# Altium schematic sheet EVB-NORA-B2_SCHEM_0001_Power.SchDoc(EVB-NORA-B2_SCHEM_0001_Power)
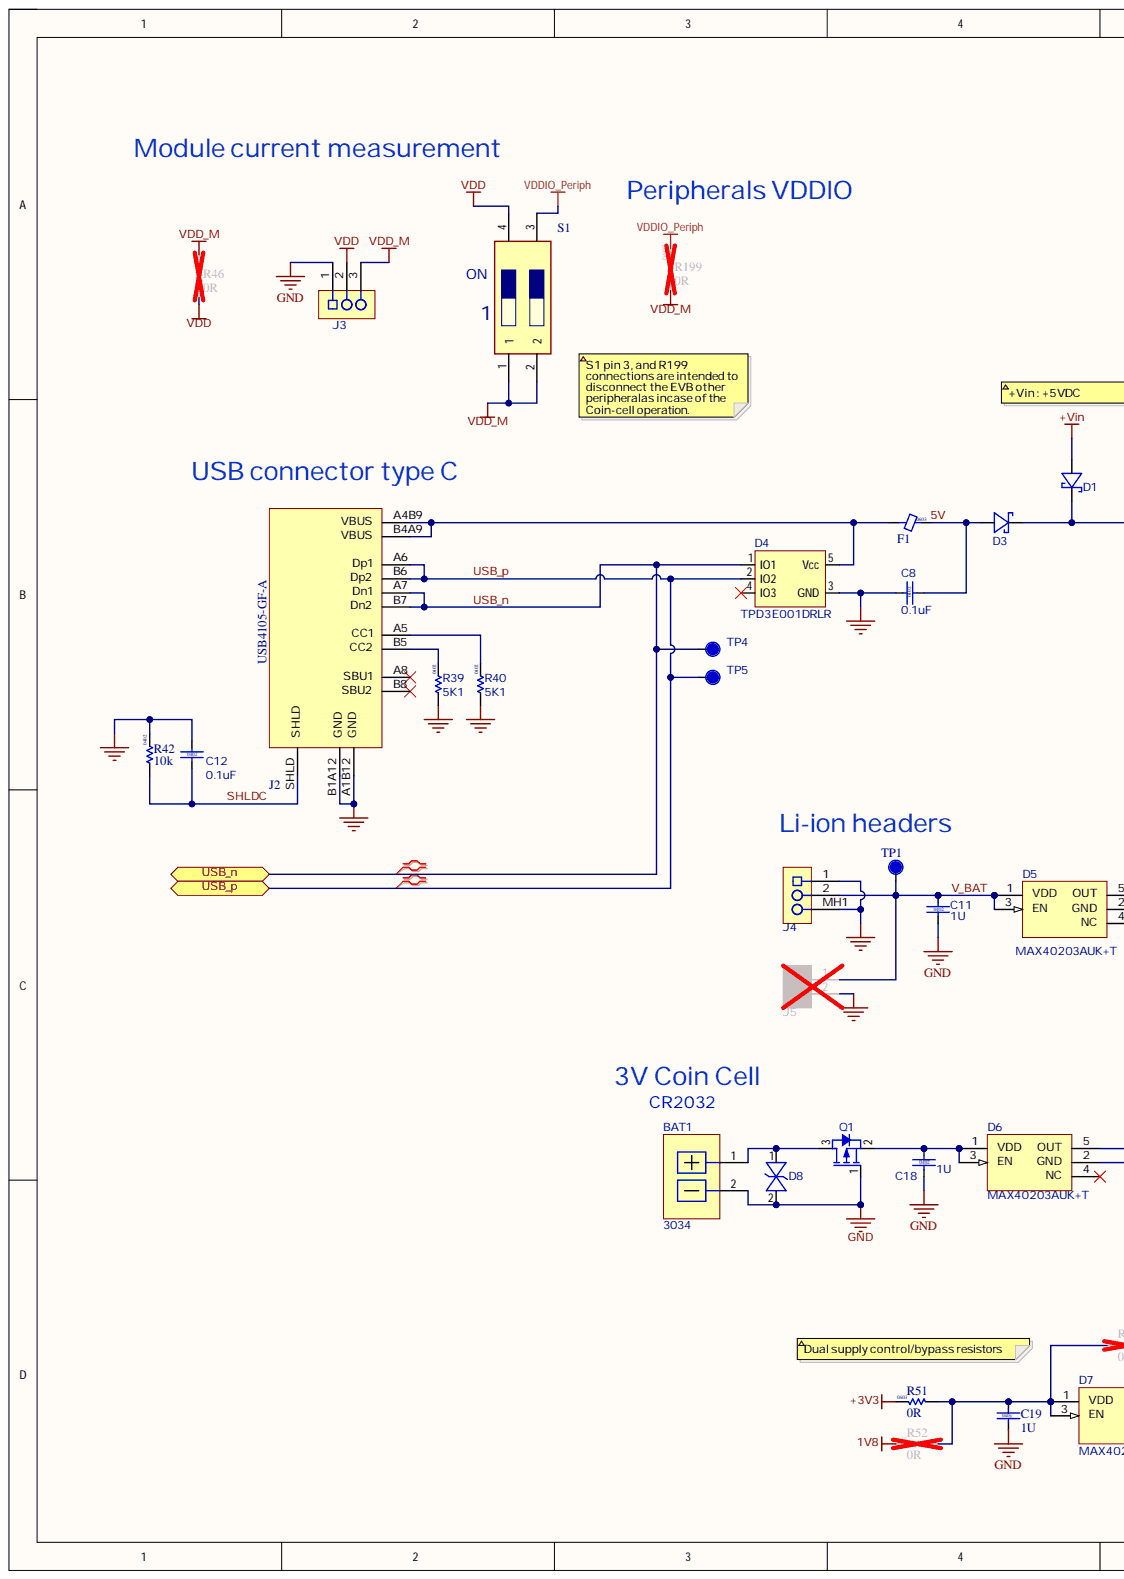
[diagram p0: EVB-NORA-B2_SCHEM_0001_Power.SchDoc, left]
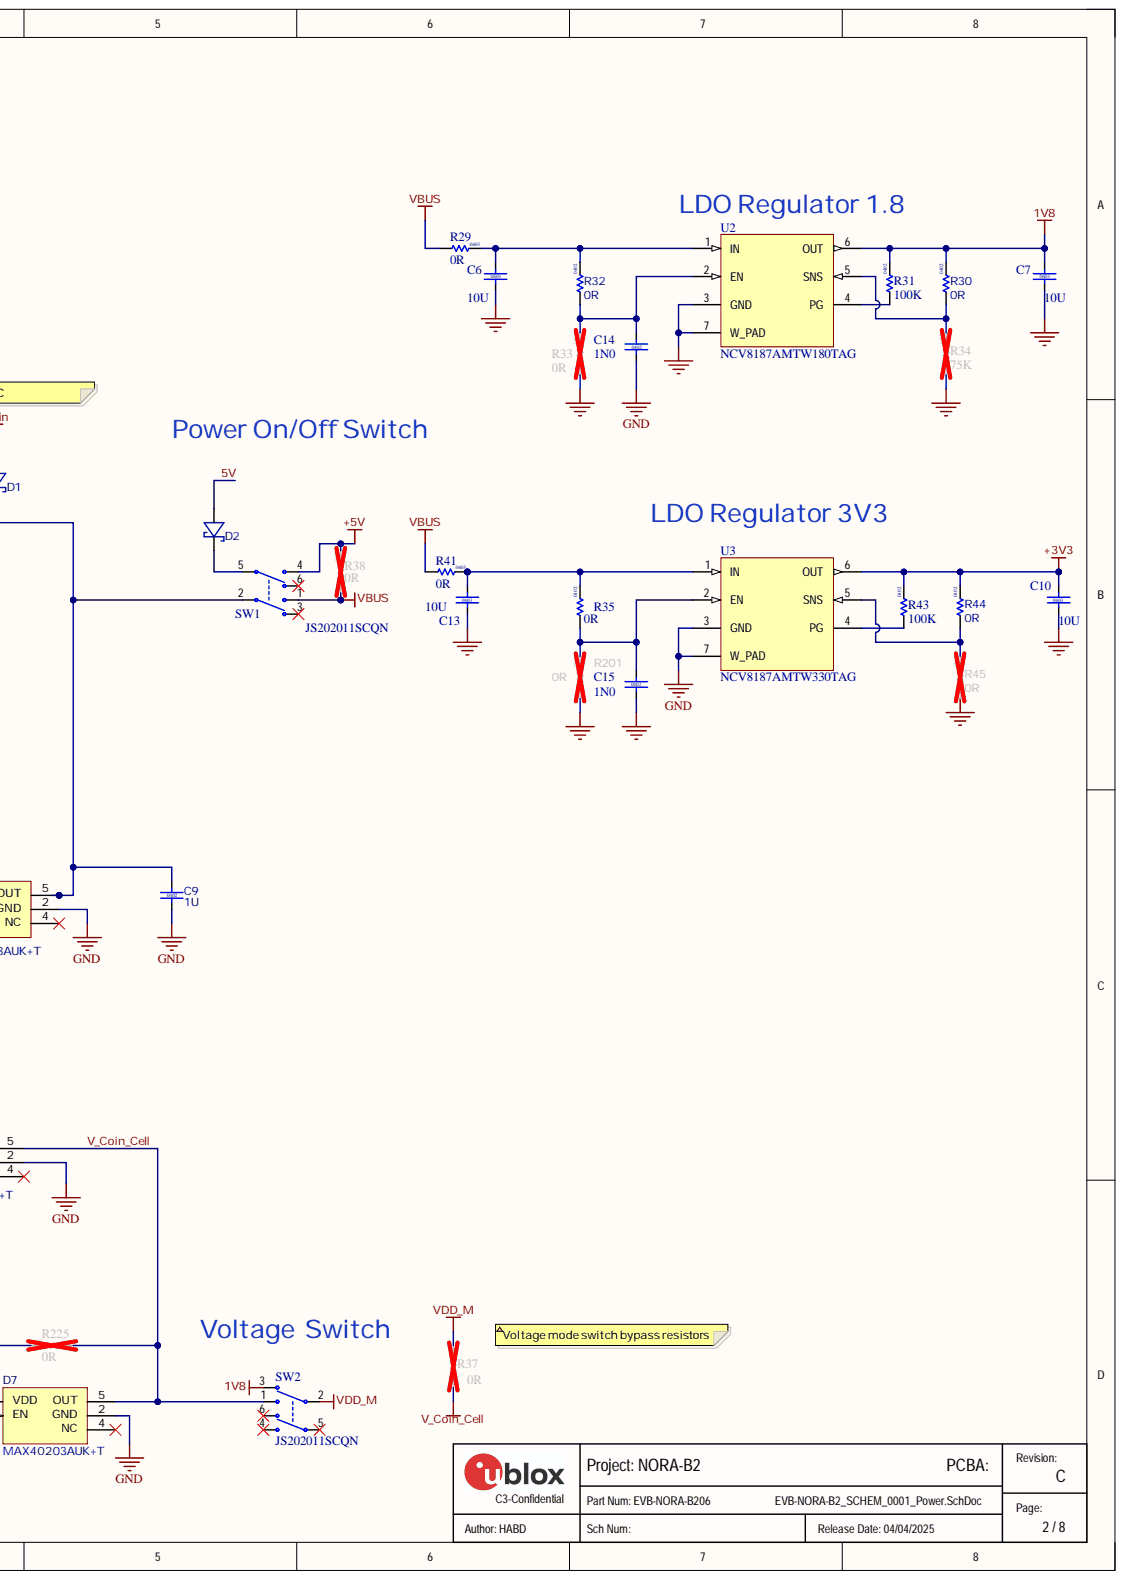
[diagram p1: EVB-NORA-B2_SCHEM_0001_Power.SchDoc, right]

## Parts
BAT1  value 3034  [3034]  (hidden comment: UBXH03-0000009)
C6  value 10U  Size: 0603  [CAP_0603_1750Lx950Wx850H_600x1000Pad_900G_FLX]  (hidden comment: UBXH01-0000310)
C7  value 10U  Size: 0603  [CAP_0603_1750Lx950Wx850H_600x1000Pad_900G_FLX]  (hidden comment: UBXH01-0000310)
C8  value 0.1uF  Size: 0402  [Cap 0402 HD]  (hidden comment: 120-00016)
C9  value 1U  Size: 0402  [Cap 0402 HD]  (hidden comment: UBXH01-0000248)
C10  value 10U  Size: 0603  [CAP_0603_1750Lx950Wx850H_600x1000Pad_900G_FLX]  (hidden comment: UBXH01-0000310)
C11  value 1U  Size: 0402  [Cap 0402 HD]  (hidden comment: UBXH01-0000248)
C12  value 0.1uF  Size: 0402  [Cap 0402 HD]  (hidden comment: 120-00016)
C13  value 10U  Size: 0603  [CAP_0603_1750Lx950Wx850H_600x1000Pad_900G_FLX]  (hidden comment: UBXH01-0000310)
C14  value 1N0  Size: 0402  [Cap 0402 HD]  (hidden comment: UBXH01-0000013)
C15  value 1N0  Size: 0402  [Cap 0402 HD]  (hidden comment: UBXH01-0000013)
C18  value 1U  Size: 0402  [Cap 0402 HD]  (hidden comment: UBXH01-0000248)
C19  value 1U  Case/Package: 0x0y  [Cap 0402 HD]
D1  110-00005  [SOD-123F_U]  (value not drawn)
D2  110-00005  [SOD-123F_U]  (value not drawn)
D3  110-00005  [SOD-123F_U]  (value not drawn)
D4  Manufacturer P/N: TPD3E001DRLR  [SOT-553]  (hidden comment: 110-00018)
D5  value MAX40203AUK+T  Manufacturer P/N: MAX40203AUK+T  [SOT-23-5_2]  (hidden comment: UBXH12-0000539)
D6  value MAX40203AUK+T  Manufacturer P/N: MAX40203AUK+T  [SOT-23-5_2]  (hidden comment: UBXH12-0000539)
D7  value MAX40203AUK+T  Manufacturer P/N: MAX40203AUK+T  [SOT-23-5_2]  (hidden comment: UBXH12-0000539)
D8  UBXH07-0000034  [SOD-923]  (value not drawn)
F1  Size: 0603  [IND_0603_1750Lx950Wx1050H_600x800Pad_800G_FLX]  (hidden comment: UBXH08-0000001)
J2  value USB4105-GF-A  [USB4105-GF-A]  (hidden comment: UBXH04-0000785)
J3  UBXH04-0000792  [HDR-0.1P-3Pin-1Row-SMT]  (value not drawn)
J4  UBXH04-0000791  [B2B-PH-SM4-TB]  (value not drawn)
J5  UBXH04-0000026  [HDR-0.1P-2PIN-TH]  (value not drawn)
Q1  140-00005  [SOT-723]  (value not drawn)
R29  value 0R  Size: 0603  [RES_0603_1700Lx950Wx600H_600x1000Pad_800G_FLX]  (hidden comment: UBXH18-0003001)
R30  value 0R  Size: 0402  [RES 0402 HD]  (hidden comment: UBXH18-0003002)
R31  value 100K  Size: 0402  [RES 0402 HD]
R32  value 0R  Size: 0402  [RES 0402 HD]
R33  value 0R  Size: 0402  [RES 0402 HD]
R34  value 75K  Size: 0402  [RES_0402_1100Lx600Wx400H_500x600Pad_400G_FLX]
R35  value 0R  Size: 0402  [RES 0402 HD]
R37  value 0R  Size: 0603  [RES_0603_1700Lx950Wx600H_600x1000Pad_800G_FLX]
R38  value 0R  Size: 0603  [RES_0603_1700Lx950Wx600H_600x1000Pad_800G_FLX]  (hidden comment: UBXH18-0003001)
R39  value 5K1  Size: 0402  [RES 0402 HD]  (hidden comment: UBXH18-0008066)
R40  value 5K1  Size: 0402  [RES 0402 HD]  (hidden comment: UBXH18-0008066)
R41  value 0R  Size: 0603  [RES_0603_1700Lx950Wx600H_600x1000Pad_800G_FLX]  (hidden comment: UBXH18-0003001)
R42  value 10k  Size: 0402  [RES 0402 HD]  (hidden comment: 180-00024)
R43  value 100K  Size: 0402  [RES 0402 HD]  (hidden comment: UBXH18-0000005)
R44  value 0R  Size: 0402  [RES 0402 HD]  (hidden comment: UBXH18-0003002)
R45  value 0R  Size: 0402  [RES 0402 HD]
R46  value 0R  Size: 0603  [RES_0603_1700Lx950Wx600H_600x1000Pad_800G_FLX]
R51  value 0R  Size: 0603  [RES_0603_1700Lx950Wx600H_600x1000Pad_800G_FLX]  (hidden comment: UBXH18-0003001)
R52  value 0R  Size: 0603  [RES_0603_1700Lx950Wx600H_600x1000Pad_800G_FLX]
R199  value 0R  Size: 0603  [RES_0603_1700Lx950Wx600H_600x1000Pad_800G_FLX]  (hidden comment: UBXH18-0003001)
R201  value 0R  Size: 0402  [RES 0402 HD]
R225  value 0R  Size: 0603  [RES_0603_1700Lx950Wx600H_600x1000Pad_800G_FLX]  (hidden comment: UBXH18-0003001)
S1  (no value)  [2182LPSTJ]
SW1  value JS202011SCQN  Manufacturer P/N: JS202011SCQN  [SW_Slide_DPDT_JS202011SCQN]  (hidden comment: UBXH19-0000050)
SW2  value JS202011SCQN  Manufacturer P/N: JS202011SCQN  [SW_Slide_DPDT_JS202011SCQN]  (hidden comment: UBXH19-0000050)
TP1  TP10R  [TP10R]  (value not drawn)
TP4  TP10R  [TP10R]  (value not drawn)  (no BOM)
TP5  TP10R  [TP10R]  (value not drawn)  (no BOM)
U2  Manufacturer Part Number 1: NCV8187AMTW180TAG  [DFN0.65P-6Pin_W-Pad]  (hidden comment: UBXH43-0000004)
U3  Manufacturer Part Number 1: NCV8187AMTW330TAG  [DFN0.65P-6Pin_W-Pad]  (hidden comment: UBXH43-0000003)

## Ports
USB_n, USB_p

## Nets
1V8: C7.1 R30.2 R31.2 R52.2 SW2.3 U2.6(OUT)
+3V3: C10.1 R43.2 R44.2 R51.2 U3.6(OUT)
+Vin: D1.A
GND: BAT1.2 C6.2 C7.2 C8.1 C9.2 C10.2 C11.2 C12.1 C13.2 C14.2 C15.1 C18.2 C19.2 D4.3(GND) D5.2(GND) D6.2(GND) D7.2(GND) D8.2 J2.A1B12(GND) J2.B1A12(GND) J3.1 J4.1 J4.MH1 J5.2 Q1.1 R33.1 R34.1 R39.1 R40.1 R42.2 R45.1 R201.1 U2.3(GND) U2.7(W_PAD) U3.3(GND) U3.7(W_PAD)
VBUS: R29.1 R38.1 R41.1 SW1.1
VDD: J3.2 R46.1 S1.4
VDD_M: J3.3 R37.1 R46.2 R199.1 S1.1 S1.2 SW2.2
VDDIO_Periph: R199.2 S1.3
5V: C8.2 D2.A D3.A F1.2 R38.2 SW1.4
SHLDC: C12.2 J2.SHLD R42.1
USB_n: D4.1(IO1) J2.A7(Dn1) J2.B7(Dn2) TP4.1 ; port USB_n
USB_p: D4.2(IO2) J2.A6(Dp1) J2.B6(Dp2) TP5.1 ; port USB_p
V_BAT: C11.1 D5.1(VDD) D5.3(EN) J4.2 J5.1 TP1.1
V_Coin_Cell: D6.5(OUT) D7.5(OUT) R37.2 R225.1 SW2.1
NetBAT1_1: BAT1.1 D8.1 Q1.3
NetC6_1: C6.1 R29.2 R32.2 U2.1(IN)
NetC9_1: C9.1 D1.C D3.C D5.5(OUT) SW1.2
NetC13_1: C13.1 R35.2 R41.2 U3.1(IN)
NetC14_1: C14.1 R32.1 R33.2 U2.2(EN)
NetC15_2: C15.2 R35.1 R201.2 U3.2(EN)
NetC18_1: C18.1 D6.1(VDD) D6.3(EN) Q1.2
NetC19_1: C19.1 D7.1(VDD) D7.3(EN) R51.1 R52.1 R225.2
NetD2_C: D2.C SW1.5
NetD4_5: D4.5(Vcc) F1.1 J2.A4B9(VBUS) J2.B4A9(VBUS)
NetJ2_A5: J2.A5(CC1) R40.2
NetJ2_B5: J2.B5(CC2) R39.2
NetR30_1: R30.1 R34.2 U2.5(SNS)
NetR31_1: R31.1 U2.4(PG)
NetR43_1: R43.1 U3.4(PG)
NetR44_1: R44.1 R45.2 U3.5(SNS)

Not connected: D4 4(IO3); D5 4(NC); D6 4(NC); D7 4(NC); J2 A8(SBU1) B8(SBU2); SW1 3 6; SW2 4 5 6

## Library data of the parts
BAT1 = UBXH03-0000009: BATTERY HOLDER COIN SMT CR2020 CR2025 CR2032 KEYSTONE 3034; Manufacturer: Keystone Electronics Corporation; Database Table Name: Electromechanical - Battery; Editor: eric.moog; Env: ROHS; Mfg P/N Released: No; Mfg P/N Split: 100; Mfg P/N Status: Active; Perferred: PREF; Permitted Use: Support Products; Component Kind: Standard; Library Name: UBX_Parts_DB_Lib.DbLib; Manufacturer P/N: 3034; Max Operating Temp: 125°C; Mfg P/N Datasheet: https://wiki.u-blox.com/bin/view/Generic/Docs/DocumentSummary?index=38835; Min Operating Temp: -55°C; Part Number: UBXH03-0000009; Part Type: Battery; RefDes: BT; UBX Wiki: https://wiki.u-blox.com/bin/view/Operations/PartDetails?index=38836
C6 C7 C10 C13 = UBXH01-0000310: CAP CER X7R 0603 10U 20% 10V; Manufacturer: Murata Manufacturing Company, Ltd.; Manufacturer P/N: GRM188Z71A106MA73; Database Table Name: Passive - Capacitor; Editor: michael.hein; Env: ROHS; Mfg P/N Released: Yes; Mfg P/N Split: 100; Mfg P/N Status: Active; Perferred: PREF; Permitted Use: Volume Products; Released by: martin.grasse; Component Kind: Standard; Library Name: UBX_Parts_DB_Lib.DbLib; Manufacturer 2: Murata Manufacturing Company, Ltd.; Manufacturer P/N 2: GRM188Z71A106KA73; Max Operating Temp: 125°C; Max Voltage: 10V; Mfg P/N Datasheet: https://wiki.u-blox.com/bin/view/Generic/Docs/DocumentSummary?index=26282; Mfg P/N Datasheet 2: https://wiki.u-blox.com/bin/view/Generic/Docs/DocumentSummary?index=28416; Mfg P/N Released 2: Yes; Mfg P/N Split 2: 0; Mfg P/N Status 2: Active; Min Operating Temp: -55°C; Part Number: UBXH01-0000310; Part Type: Capacitor; RefDes: C; Release Data: 2017-02-09T11:14:05; Signal Integrity: Cap Semi; Simulation: CAP; Tolerance: 20%; UBX Wiki: https://wiki.u-blox.com/bin/view/Operations/PartDetails?index=26280
  C10 C13: Case/Package: 0x0y
C8 C12 = 120-00016: Cap, Ceramic 0.1uF 10V 10% X5R 0402; Manufacturer: Murata Electronics North America; Manufacturer P/N: GRM155R61A104KA01J; Part Name: CAP CER 0.1UF 10V 10% X5R 0402; Component Mounting Type: SMT; Database Table Name: Ceramic Capacitor; Item_Phase: Production; Tolerance: +/- 10%; Use in new designs: Yes; Arena Link: https://app.bom.com/a/GYHPV2L2L43KRMBK?v=spec.summary; Component Kind: Standard; Height: 0.55mm; Manufacturer 2: Yageo; Manufacturer P/N 2: CC0402KRX5R6BB104; Part Number: 120-00016; Part_Type: Ceramic Capacitor; Pins: 2; Revision: 0A; Signal Integrity: Cap Semi; Simulation: CAP; Supplier 1: Digi-Key; Supplier 2: Digi-Key; Supplier Part Number 1: 490-6297-1-ND; Supplier Part Number 2: 311-1336-1-ND; Temperature Coefficient: X5R; Voltage: 10V; Library Name: Arena_Parts_DB_Lib.DbLib
C9 C11 C18 C19 = UBXH01-0000248: CAP CER X5R 0402 1U 10% 10V; Manufacturer: Murata Manufacturing Company, Ltd.; Manufacturer P/N: GRM155R61C105KA12; Database Table Name: Passive - Capacitor; Editor: michael.hein; Env: ROHS; Mfg P/N Released: Yes; Mfg P/N Split: 100; Mfg P/N Status: Active; Perferred: PREF; Permitted Use: Volume Products; Released by: igor.shevchenko; Component Kind: Standard; Library Name: UBX_Parts_DB_Lib.DbLib; Manufacturer 2: TDK Corporation; Manufacturer 3: Samsung Electro-Mechanics; Manufacturer P/N 2: C1005X5R1E105K050BC; Manufacturer P/N 3: CL05A105KA5NQNC; Max Operating Temp: 85°C; Max Voltage: 10V; Mfg P/N Datasheet: https://wiki.u-blox.com/bin/view/Generic/Docs/DocumentSummary?index=29581; Mfg P/N Datasheet 2: https://wiki.u-blox.com/bin/view/Generic/Docs/DocumentSummary?index=28058; Mfg P/N Datasheet 3: https://wiki.u-blox.com/bin/view/Generic/Docs/DocumentSummary?index=28056; Mfg P/N Released 2: Yes; Mfg P/N Released 3: Yes; Mfg P/N Split 2: 0; Mfg P/N Split 3: 0; Mfg P/N Status 2: Active; Mfg P/N Status 3: Active; Min Operating Temp: -40°C; Part Number: UBXH01-0000248; Part Type: Capacitor; RefDes: C; Release Data: 2016-03-16T11:26:35; Signal Integrity: Cap Semi; Simulation: CAP; Tolerance: 10%; UBX Wiki: https://wiki.u-blox.com/bin/view/Operations/PartDetails?index=24378
  C19: Comment: ="Part Number" + Value + DNP; Size: 0402
C14 C15 = UBXH01-0000013: CAP CER X7R 0402 1N0 10% 50V; Manufacturer: Murata Manufacturing Company, Ltd.; Manufacturer P/N: GRM155R71H102KA01; Database Table Name: Passive - Capacitor; Editor: massimo.vlacci; Env: ROHS; Mfg P/N Released: Yes; Mfg P/N Split: 100; Mfg P/N Status: NRND; Perferred: PREF; Permitted Use: Volume Products; Released by: dario.antonaz; Case/Package: 0x0y; Component Kind: Standard; Library Name: UBX_Parts_DB_Lib.DbLib; Max Voltage: 50V; Mfg P/N Datasheet: https://wiki.u-blox.com/bin/view/Generic/Docs/DocumentSummary?index=22572; Part Number: UBXH01-0000013; Part Type: Capacitor; RefDes: C; Release Data: 2015-04-23T14:26:43; Signal Integrity: Cap Semi; Simulation: CAP; Tolerance: 10%; UBX Wiki: https://wiki.u-blox.com/bin/view/Operations/PartDetails?index=8466
D1-D3 = 110-00005: Diode, Schottky, 20V 1A SOD-123F, 340mV Vf @ 1A MBR120VLSFT3G; Manufacturer 1: ON Semiconductor; Part Name: Diode Schottky 20V 1A SOD-123FL; Body Size: None; Item Phase: In Production; MFG Package: SOD-123FL; Use in new designs: Yes; Component Kind: Standard; Current: 1A; Database Table Name: Diode; Height: 0.98mm; Manufacturer 2: None; Manufacturer Part Number 1: MBR120VLSFT3G; Manufacturer Part Number 2: None; Max Operating Temp: 125; Min Operating Temp: -65; Part Number: 110-00005; Pins: 2; Revision: 0B; Supplier 1: Digi-Key; Supplier 2: None; Supplier Part Number 1: MBR120VLSFT3GOSCT-ND; Supplier Part Number 2: None; UBX Code: None; Voltage: 20V; Library Name: Arena_Parts_DB_Lib.DbLib
D4 = 110-00018: LOW-CAPACITANCE 3-CHANNEL ESD-PROTECTION ARRAY; Manufacturer: Texas Instruments; Part Name: TVS Diode, 3CH High Speed ESD; Body Size: 1.6mm x 1.2mm; Component Mounting Type: SMT; Database Table Name: Diode; Diode Type: TVS; Item_Phase: Production; Use in new designs: Yes; Voltage: 5V; Arena Link: https://app.bom.com/a/UCV39GZGZIHX50AE?v=spec.summary; Component Kind: Standard; Height: .55mm; MFG Package: SOT-553; Package Type: SOT; Part Number: 110-00018; Part_Type: Diode; Pins: 5; Revision: 0A; Supplier 1: Digi-Key; Supplier Part Number 1: 296-21885-1-ND; Library Name: Arena_Parts_DB_Lib.DbLib
D5-D7 = UBXH12-0000539: IDEAL DIODE OR CONTROLLER SOURCE SELECTOR SWITCH P-CHANNEL 1:1 SOT-23-5 5.5V 1A; Manufacturer: Maxim; Part Name: IC IDEAL DIODE LDO 1.2-5.5V 1.0A SOT-23-5; Body Size: 2.8mm x 2.9mm; Component Mounting Type: SMT; Current: 1.0A; Database Table Name: IC - PMIC; Editor: eric.moog; Env: ROHS; Item_Phase: Preliminary; Mfg P/N Released: No; Mfg P/N Split: 100; Mfg P/N Status: Active; Permitted Use: Support Products; SMT Pitch: 0.95mm; Use in new designs: Yes; Component Kind: Standard; Height: 1.25; HelpURL: https://datasheets.maximintegrated.com/en/ds/MAX40203.pdf; Library Name: UBX_Parts_DB_Lib.DbLib; Manufacturer 1: Maxim; Manufacturer Part Number 1: MAX40203AUK+T; Max Current: 1A; Max Voltage: 5.5V; Mfg P/N Datasheet: https://wiki.u-blox.com/bin/view/Generic/Docs/DocumentSummary?index=38598; MFG Package: SOT-23-5; Package Type: SOT-23-5; Part Number: UBXH12-0000539; Part Type: PMIC; Part_Type: Ideal Diode; Perferred: N/A; Pins: 5; RefDes: U; Supplier 1: Digi-Key; Supplier Part Number 1: 175-MAX40203AUK+TTR-ND; UBX Wiki: https://wiki.u-blox.com/bin/view/Operations/PartDetails?index=38597; Voltage: 5.5V
D8 = UBXH07-0000034: ESD PROTECTION DIODE ULTRA LOW LEAKAGE SOD923 ON SEMI 3V3; Manufacturer: ON Semiconductor; Manufacturer P/N: ESD9R3.3ST5G; Database Table Name: Discrete - Diode; Editor: pierpaolo.arban; Env: ROHS; Mfg P/N Released: Yes; Mfg P/N Split: 100; Mfg P/N Status: Active; Perferred: PREF; Permitted Use: Support Products; Released by: dario.antonaz; Component Kind: Standard; Library Name: UBX_Parts_DB_Lib.DbLib; Mfg P/N Datasheet: https://wiki.u-blox.com/bin/view/Generic/Docs/DocumentSummary?index=22449; Part Number: UBXH07-0000034; Part Type: Diode; RefDes: D; Release Data: 2015-04-23T14:51:11; UBX Wiki: https://wiki.u-blox.com/bin/view/Operations/PartDetails?index=22447; Value: 3V3
F1 = UBXH08-0000001: FERRITE BEAD 0603 600 OHM AT 100MHZ 600OHM 500mA; Manufacturer: TDK Corporation; Manufacturer P/N: MMZ1608B601C; Database Table Name: Passive - Ferrite; Editor: daniel.hurni; Env: ROHS; Mfg P/N Released: Yes; Mfg P/N Split: 100; Mfg P/N Status: Active; Perferred: PREF; Permitted Use: Support Products; Released by: martin.frauchiger; Component Kind: Standard; Library Name: UBX_Parts_DB_Lib.DbLib; Max Current: 500mA; Max Operating Temp: 125°C; Mfg P/N Datasheet: https://wiki.u-blox.com/bin/view/Generic/Docs/DocumentSummary?index=7941; Min Operating Temp: -40°C; Part Number: UBXH08-0000001; Part Type: Ferrite; RefDes: FB; Release Data: 2009-12-02T10:18:36; UBX Wiki: https://wiki.u-blox.com/bin/view/Operations/PartDetails?index=7960; Value: 600OHM
J2 = UBXH04-0000785: CONN RCP USB2.0 TYP C 24P SMD RA 20V 6.25A; Manufacturer: GCT; Body Size: 7.3mm x 8.9mm; Database Table Name: Electromechanical - Connector; Editor: eric.moog; Env: ROHS; Item Phase: Unreleased; Mfg P/N Released: No; Mfg P/N Split: 100; Mfg P/N Status: Active; Perferred: PREF; Permitted Use: Support Products; SMT Pitch: 0.5mm; UBX Code: None; Use in new designs: Yes; Voltage: <20V DC; Component Kind: Standard; Height: 3.31mm; Library Name: UBX_Parts_DB_Lib.DbLib; Manufacturer 1: GCT; Manufacturer 2: None; Manufacturer P/N: USB4105-GF-A; Manufacturer Part Number 1: USB4105-GF-A; Manufacturer Part Number 2: None; Max Current: 6.25A; Max Operating Temp: 85°C; Max Voltage: 20V; Mfg P/N Datasheet: https://wiki.u-blox.com/bin/view/Generic/Docs/DocumentSummary?index=38208; Min Operating Temp: -40°C; Part Number: UBXH04-0000785; Part Type: Connector; Pins: 8; RefDes: J; Revision: None; Size: Other; Supplier 2: None; Supplier Part Number 2: None; UBX Wiki: https://wiki.u-blox.com/bin/view/Operations/PartDetails?index=38207
J3 = UBXH04-0000792: 1-ROW SMD CONN 100MIL GRID 3 -PIN 100V 3A; Manufacturer: HARWiN; Manufacturer P/N: M20-8770342; Database Table Name: Electromechanical - Connector; Editor: eric.moog; Env: ROHS; Mfg P/N Released: No; Mfg P/N Split: 100; Mfg P/N Status: Active; Permitted Use: Support Products; Value: M20-8770342; Component Kind: Standard; Library Name: UBX_Parts_DB_Lib.DbLib; Max Current: 3A; Max Operating Temp: 105°C; Max Voltage: 100V; Mfg P/N Datasheet: https://wiki.u-blox.com/bin/view/Generic/Docs/DocumentSummary?index=28457; Min Operating Temp: -40°C; Part Number: UBXH04-0000792; Part Type: Connector; Perferred: N/A; RefDes: J; UBX Wiki: https://wiki.u-blox.com/bin/view/Operations/PartDetails?index=38569
J4 = UBXH04-0000791: CONN 1-ROW SMT 2.0MM GRID 2-PIN VERT 100V 2A; Manufacturer: JST; Part Name: Conn, SMT, 0.079" Pitch, 2 Pin, Vert, Black; Database Table Name: Electromechanical - Connector; Editor: eric.moog; Env: ROHS; Item Phase: In Production; Mfg P/N Released: No; Mfg P/N Split: 100; Mfg P/N Status: Active; Permitted Use: Support Products; UBX Code: None; Use in new designs: Yes; Value: B2B-PH-SM4-TB; Component Kind: Standard; Diameter: None; Height: 6.5mm; Library Name: UBX_Parts_DB_Lib.DbLib; Manufacturer 2: None; Manufacturer P/N: B2B-PH-SM4-TB; Manufacturer Part Number 1: B2B-PH-SM4-TB; Manufacturer Part Number 2: None; Max Current: 2A; Max Operating Temp: 85°C; Max Voltage: 100V; Mfg P/N Datasheet: https://wiki.u-blox.com/bin/view/Generic/Docs/DocumentSummary?index=35463; Min Operating Temp: -30°C; Part Number: UBXH04-0000791; Part Type: Connector; Perferred: N/A; Pins: 2; RefDes: J; Revision: 0A; Supplier 1: None; Supplier 2: None; Supplier Part Number 1: None; Supplier Part Number 2: None; UBX Wiki: https://wiki.u-blox.com/bin/view/Operations/PartDetails?index=38567; Voltage: None
J5 = UBXH04-0000026: 1-ROW TH-PCB SOCKETS 100MIL GRID 2-PIN 0.64MM SQUARE POLARIZE; Manufacturer: HARWiN; Manufacturer P/N: M20-9990245; Database Table Name: Electromechanical - Connector; Editor: roland.rust; Env: ROHS; Mfg P/N Released: Yes; Mfg P/N Split: 100; Mfg P/N Status: Active; Perferred: PREF; Permitted Use: Internal Use; Released by: alvaro.nussbaum; Value: M20-9990245; Component Kind: Standard; Library Name: UBX_Parts_DB_Lib.DbLib; Part Number: UBXH04-0000026; Part Type: Connector; RefDes: J; Release Data: 2015-06-04T16:45:09; UBX Wiki: https://wiki.u-blox.com/bin/view/Operations/PartDetails?index=10937
Q1 = 140-00005: P-MOSFET, 20V, 100mA, SOT-723-3; Manufacturer 1: Rohm Semiconductor; Manufacturer Part Number 1: RZM001P02T2L; Part Name: MOSFET P-CH 20V 0.1A VMT3; Body Size: 1.2mm x 0.8mm; Database Table Name: MOSFET; Item Phase: In Production; Thermal Pad: No; Transistor Type: P-Channel; UBX Code: None; Use in new designs: Yes; Component Kind: Standard; Current: 100mA; Height: 0.5mm; Manufacturer 2: Toshiba Semiconductor and Storage; Manufacturer Part Number 2: SSM3J35MFV,L3F; Max Operating Temp: 150; MFG Package: SOT-723; Min Operating Temp: -55; Part Number: 140-00005; Pins: 3; Revision: 0B; Supplier 1: Digi-Key; Supplier 2: Digi-Key; Supplier Part Number 1: RZM001P02T2LCT-ND; Supplier Part Number 2: SSM3J35MFVL3FCT-ND; Voltage: 20V; Library Name: Arena_Parts_DB_Lib.DbLib
R29 R37 R38 R41 R46 R51 R52 R199 R225 = UBXH18-0003001: RES THICK FILM CHIP 0603 0R 0 100mW; Manufacturer: YAGEO; Manufacturer P/N: RC0603JR-070RL; Database Table Name: Passive - Resistor; Editor: martin.frauchiger; Env: ROHS; Mfg P/N Released: Yes; Mfg P/N Split: 100; Mfg P/N Status: Active; Perferred: PREF; Permitted Use: Volume Products; Tolerance: 0; Component Kind: Standard; Library Name: UBX_Parts_DB_Lib.DbLib; Max Power: 100mW; Part Number: UBXH18-0003001; Part Type: Resistor; RefDes: R; Release Data: 2005-07-14T10:55:51; Signal Integrity: Res; Simulation: RESISTOR; UBX Wiki: https://wiki.u-blox.com/bin/view/Operations/PartDetails?index=6609; Released by: martin.frauchiger
  R37 R46 R52: Comment: = "Part Number" + Value + DNP
  R199: Case/Package: 0x0y
R30 R32 R44 R45 R201 = UBXH18-0003002: RES THICK FILM CHIP 0402 0R 0 100mW; Manufacturer: KOA; Manufacturer P/N: RK73Z1ETTP; Database Table Name: Passive - Resistor; Editor: charlotte.biber; Env: ROHS; Mfg P/N Released: Yes; Mfg P/N Split: 100; Mfg P/N Status: NRND; Perferred: PREF; Permitted Use: Volume Products; Released by: peter.mueller; Tolerance: 0; Component Kind: Standard; Library Name: UBX_Parts_DB_Lib.DbLib; Manufacturer 2: YAGEO; Manufacturer P/N 2: 2322_705_91001_L; Max Power: 100mW; Mfg P/N Released 2: Yes; Mfg P/N Split 2: 0; Mfg P/N Status 2: NRND; Part Number: UBXH18-0003002; Part Type: Resistor; RefDes: R; Release Data: 2012-06-05 09:49:55.978616; Signal Integrity: Res; Simulation: RESISTOR; UBX Wiki: https://wiki.u-blox.com/bin/view/Operations/PartDetails?index=6611
  R32 R45 R201: Comment: = "Part Number" + Value + DNP
R31 R43 = UBXH18-0000005: RES THICK FILM CHIP 0402 100K 1% 63mW; Manufacturer: KOA; Manufacturer P/N: RK73H1ETTP1003F; Database Table Name: Passive - Resistor; Editor: dario.antonaz; Env: ROHS; Mfg P/N Released: Yes; Mfg P/N Split: 100; Mfg P/N Status: Active; Permitted Use: Volume Products; Released by: sandro.sestan; Component Kind: Standard; Library Name: UBX_Parts_DB_Lib.DbLib; Max Power: 63mW; Mfg P/N Datasheet: https://wiki.u-blox.com/bin/view/Generic/Docs/DocumentSummary?index=8222; Mfg P/N Released 2: Yes; Mfg P/N Split 2: 0; Part Number: UBXH18-0000005; Part Type: Resistor; Perferred: N/A; RefDes: R; Release Data: 2009-11-30T11:05:22; Signal Integrity: Res; Simulation: RESISTOR; Tolerance: 1%; UBX Wiki: https://wiki.u-blox.com/bin/view/Operations/PartDetails?index=8114
  R31: Case/Package: 0x0y; Comment: = "Part Number" + Value + DNP; Manufacturer 2: YAGEO; Manufacturer 3: ROHM; Manufacturer P/N 2: RC0402FR-07100KL; Manufacturer P/N 3: MCR01MZPF1003; Mfg P/N Datasheet 2: https://wiki.u-blox.com/bin/view/Generic/Docs/DocumentSummary?index=29373; Mfg P/N Datasheet 3: https://wiki.u-blox.com/bin/view/Generic/Docs/DocumentSummary?index=8186; Mfg P/N Released 3: Yes; Mfg P/N Split 3: 0; Mfg P/N Status 2: Active; Mfg P/N Status 3: Obsolete
  R43: Manufacturer 2: ROHM; Manufacturer P/N 2: MCR01MZPF1003; Mfg P/N Datasheet 2: https://wiki.u-blox.com/bin/view/Generic/Docs/DocumentSummary?index=8186; Mfg P/N Status 2: Obsolete
R33 R35 = UBXH18-0003002: RES THICK FILM CHIP 0402 0R 0 100mW; Manufacturer: KOA; Manufacturer P/N: RK73Z1ETTD; Database Table Name: Passive - Resistor; Editor: charlotte.biber; Env: ROHS; Mfg P/N Released: Yes; Mfg P/N Split: 100; Mfg P/N Status: NRND; Perferred: PREF; Permitted Use: Volume Products; Released by: peter.mueller; Tolerance: 0; Comment: = "Part Number" + Value + DNP; Component Kind: Standard; Library Name: UBX_Parts_DB_Lib.DbLib; Manufacturer 2: YAGEO; Manufacturer P/N 2: 2322_705_91001_L; Max Power: 100mW; Mfg P/N Released 2: Yes; Mfg P/N Split 2: 0; Mfg P/N Status 2: Obsolete; Part Number: UBXH18-0003002; Part Type: Resistor; RefDes: R; Release Data: 2012-06-05T09:49:55; Signal Integrity: Res; Simulation: RESISTOR; UBX Wiki: https://wiki.u-blox.com/bin/view/Operations/PartDetails?index=6611
R34 = UBXH18-0008094: RES THICK FILM CHIP 0402 75K 5% 100mW; Manufacturer: KOA; Manufacturer P/N: RK73Z1ETTD; Database Table Name: Passive - Resistor; Editor: andreas.thiel; Env: ROHS; Mfg P/N Released: Yes; Mfg P/N Split: 100; Mfg P/N Status: NRND; Perferred: NONPREF; Permitted Use: Volume Products; Released by: peter.mueller; Comment: = "Part Number" + Value + DNP; Component Kind: Standard; Library Name: UBX_Parts_DB_Lib.DbLib; Manufacturer 2: YAGEO; Manufacturer P/N 2: 2322_705_91001_L; Max Power: 100mW; Mfg P/N Released 2: Yes; Mfg P/N Split 2: 0; Mfg P/N Status 2: Obsolete; Part Number: UBXH18-0008094; Part Type: Resistor; RefDes: R; Release Data: 2012-06-05T09:49:55; Signal Integrity: Res; Simulation: RESISTOR; Tolerance: 5%; UBX Wiki: https://wiki.u-blox.com/bin/view/Operations/PartDetails?index=7017
R39 R40 = UBXH18-0008066: RES THICK FILM CHIP 0402 5K1 5% 100mW; Manufacturer: Panasonic; Manufacturer P/N: ERJ-2GEJ512X; Database Table Name: Passive - Resistor; Editor: alvaro.nussbaum; Env: ROHS; Mfg P/N Released: No; Mfg P/N Split: 100; Mfg P/N Status: Active; Perferred: NONPREF; Permitted Use: Internal Use; Released by: roland.rust; Component Kind: Standard; Library Name: UBX_Parts_DB_Lib.DbLib; Max Power: 100mW; Part Number: UBXH18-0008066; Part Type: Resistor; RefDes: R; Release Data: 2016-02-11T15:22:01; Signal Integrity: Res; Simulation: RESISTOR; Tolerance: 5%; UBX Wiki: https://wiki.u-blox.com/bin/view/Operations/PartDetails?index=6972
R42 = 180-00024: Resistor 10k ohm 1% 1/16W, 0402; Manufacturer 1: Samsung Electro-Mechanics America, Inc; Manufacturer Part Number 1: RC1005F103CS; Part Name: RES SMD 10K OHM 1% 1/16W 0402; Item Phase: In Production; Tolerance: +/- 1%; UBX Code: None; Use in new designs: Yes; Component Kind: Standard; Database Table Name: Resistor; Height: 0.4mm; Manufacturer 2: Hong Kong Resistors Manufactory; Manufacturer Part Number 2: RCT0210KFLF; Max Operating Temp: 155; Min Operating Temp: -55; Part Number: 180-00024; Pins: 2; Power: 1/16W; Revision: 0E; Signal Integrity: Res; Simulation: RESISTOR; Supplier 1: Digi-Key; Supplier 2: None; Supplier Part Number 1: 1276-3431-1-ND; Supplier Part Number 2: None; Voltage: N/A; Library Name: Arena_Parts_DB_Lib.DbLib
S1 = 218-2LPSTJ: Switch; Manufacturer_Name: CTS; Arrow Part Number: 218-2LPSTJ; Arrow Price/Stock: https://www.arrow.com/en/products/218-2lpstj/cts; Component Kind: Standard; Datasheet Link: https://www.ctscorp.com/wp-content/uploads/218.pdf; Height: 2.7mm; Library Name: SamacSys.SchLib; Manufacturer_Part_Number: 218-2LPSTJ; Mouser Part Number: 774-2182LPSTJ; Mouser Price/Stock: https://www.mouser.co.uk/ProductDetail/CTS-Electronic-Components/218-2LPSTJ?qs=qNzHFtQhdJ8h31nbRNBSWA%3D%3D
SW1 SW2 = UBXH19-0000050: 2 WAY ON-ON SUB-MINIATURE SLIDE SWITCH SMD JS SERIES; Manufacturer: C&K Components; Database Table Name: Electromechanical - Switch; Editor: andre.pick; Env: ROHS; Mfg P/N Released: Yes; Mfg P/N Split: 100; Mfg P/N Status: Active; Perferred: PREF; Permitted Use: Support Products; Released by: martin.pilik; Component Kind: Standard; Library Name: UBX_Parts_DB_Lib.DbLib; Max Operating Temp: 85°C; Mfg P/N Datasheet: https://wiki.u-blox.com/bin/view/Generic/Docs/DocumentSummary?index=16625; Min Operating Temp: -40°C; Part Number: UBXH19-0000050; Part Type: Switch; RefDes: S; Release Data: 2022-06-09T16:59:15; UBX Wiki: https://wiki.u-blox.com/bin/view/Operations/PartDetails?index=36899
TP1 TP4 TP5 = TP10R: Testpoint, Round 1.0mm Dia; Added By: Jeremy D; Database Table Name: Testpoints & Pads; DNP: DNP; Height: 0; Part Number: TP10R; Size: 1.0mm; Checked By: Paul B; Library Name: LEGACY_Rigado_AltiumLib.DbLib
  TP1: Added Date: 2015-02-01; Checked Date: 2015-02-05; Component Kind: Standard
  TP4 TP5: Added Date: 2/1/2015; Checked Date: 2/5/2015
U2 = UBXH43-0000004: LDO REGULATOR 1.8V,LOW Iq, WDFNW6 2x2 MM PACKAGE 1200 mA; Manufacturer 1: ONSEMI Conductor; Manufacturer Split 1: 100; Part Phase: Unreleased; Body Size: 2.10mm x 2.10 mm; Database Table Name: Integrated Circuit - Regulator - Linear; Environmental Grade: RoHS; Max Supply Voltage: 5.5V; Maximum Current: 1.2A; Min Supply Voltage: 1.5; Owner: Hozaifa Abdelgadir; Single Source: No; SMT Pitch: 0.65mm; Thermal Pad: Yes; Automotive: No; Case/Package: WDFNW6 2x2; Component Kind: Standard; ComponentLink1Description: Arena Link; ComponentLink1Url: https://app.bom.com/1J3MRFNPJL4CV8H3H3XG; Height: 0.8mm; Max Operating Temp: 150°C; Min Operating Temp: -40°C; Mounting Type: SMT; Output Voltage: 1.8V; Part Name: LDO REGULATOR 1.8V,LOW Iq, WDFNW6 2x2 MM PACKAGE 1200 mA; Part Number: UBXH43-0000004; Part Status: Non-Preferred; Part Type: Regulator - Linear; Pins: 6; Use in new designs: Yes; Volume Use: No; Library Name: UBX_Arena.DbLib
U3 = UBXH43-0000003: LDO REGULATOR 3.3V,LOW Iq, WDFNW6 2x2 MM, 0.65P PACKAGE 1200 mA; Manufacturer 1: ONSEMI Conductor; Manufacturer Split 1: 100; Part Name: LDO REGULATOR  3.3V,LOW Iq, WDFNW6 2x2 MM PACKAGE 1200 mA; Part Phase: Unreleased; Part Revision: 1; Body Size: 2.1mmx2.1mm; Database Table Name: Integrated Circuit - Regulator - Linear; Environmental Grade: RoHS; Max Supply Voltage: 5.5V; Maximum Current: 1.2A; Min Supply Voltage: 1.5V; Owner: Hozaifa Abdelgadir; Single Source: No; SMT Pitch: 0.65mm; Thermal Pad: Yes; Automotive: No; Case/Package: WDFNW6 2x2; Component Kind: Standard; ComponentLink1Description: Arena Link; ComponentLink1Url: https://app.bom.com/R9TCH5DF9BU2LY72VT52; Height: 0.8mm; Max Operating Temp: 150°C; Min Operating Temp: -40°C; Mounting Type: SMT; Output Voltage: 3.3V; Part Number: UBXH43-0000003; Part Status: Non-Preferred; Part Type: Regulator - Linear; Pins: 6; Use in new designs: Yes; Volume Use: No; Library Name: UBX_Arena.DbLib
